# Altium schematic sheet PABX.SchDoc(PABX)
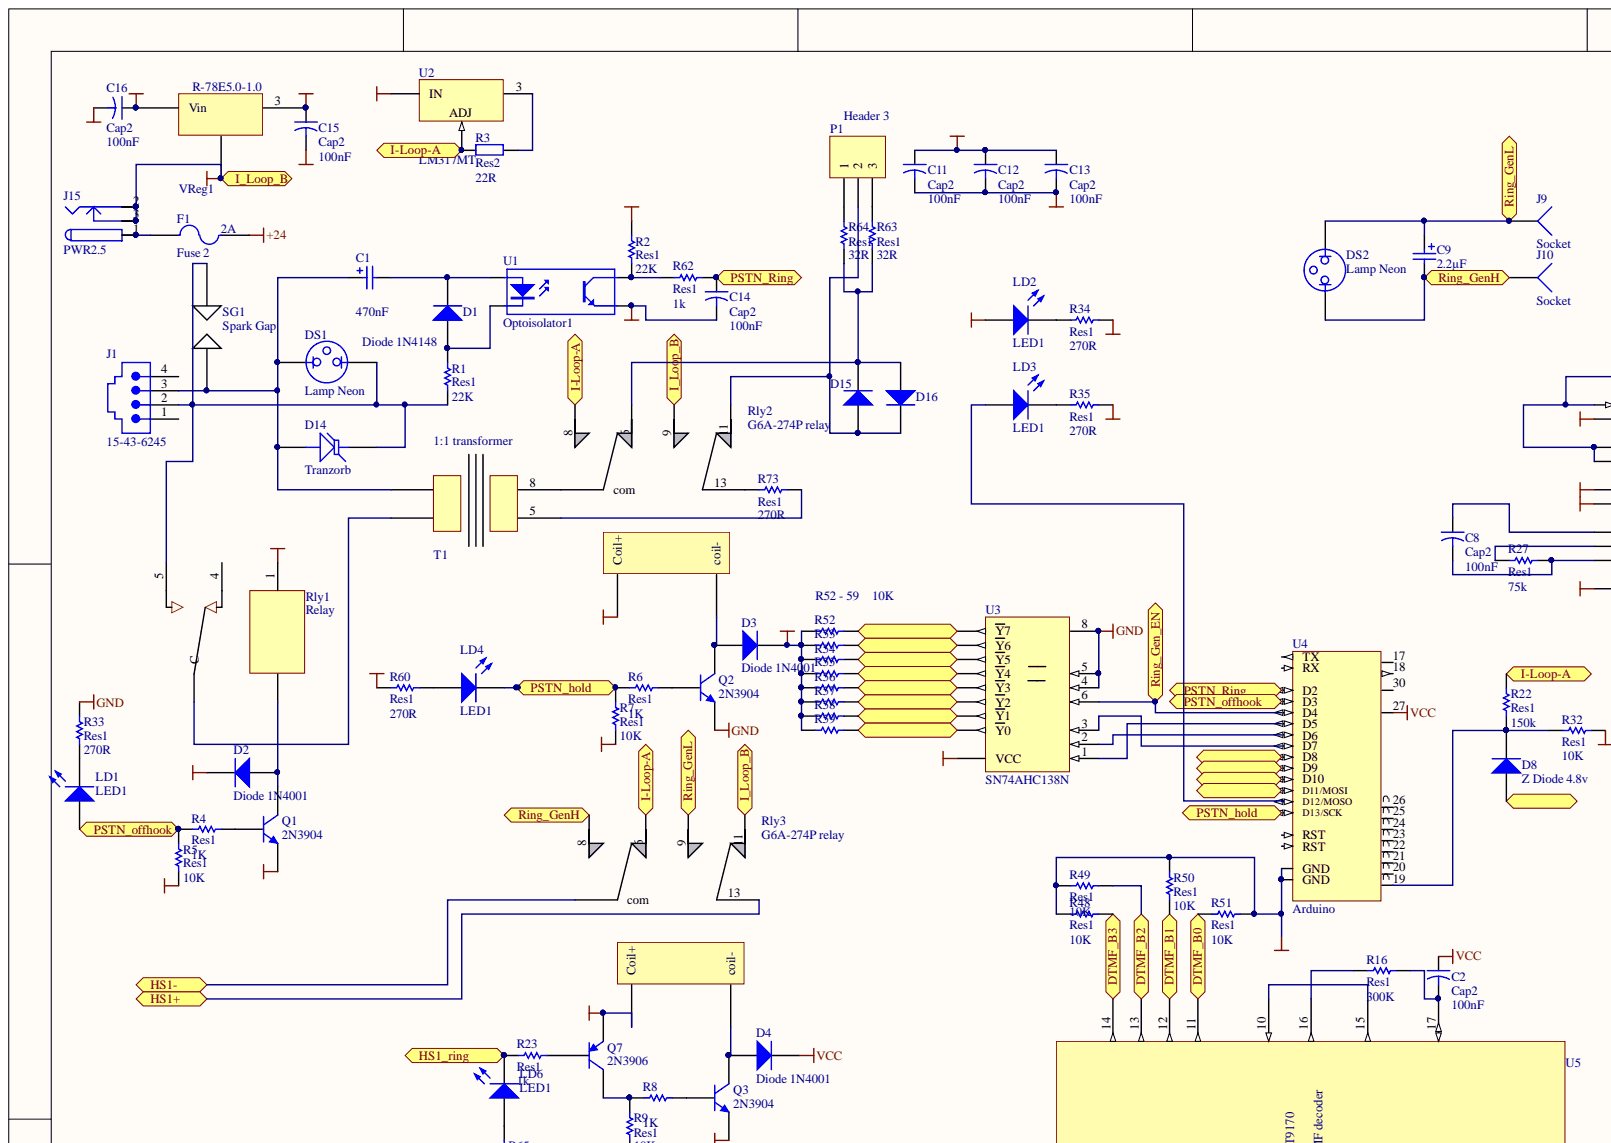
[diagram p0: PABX.SchDoc, top left]
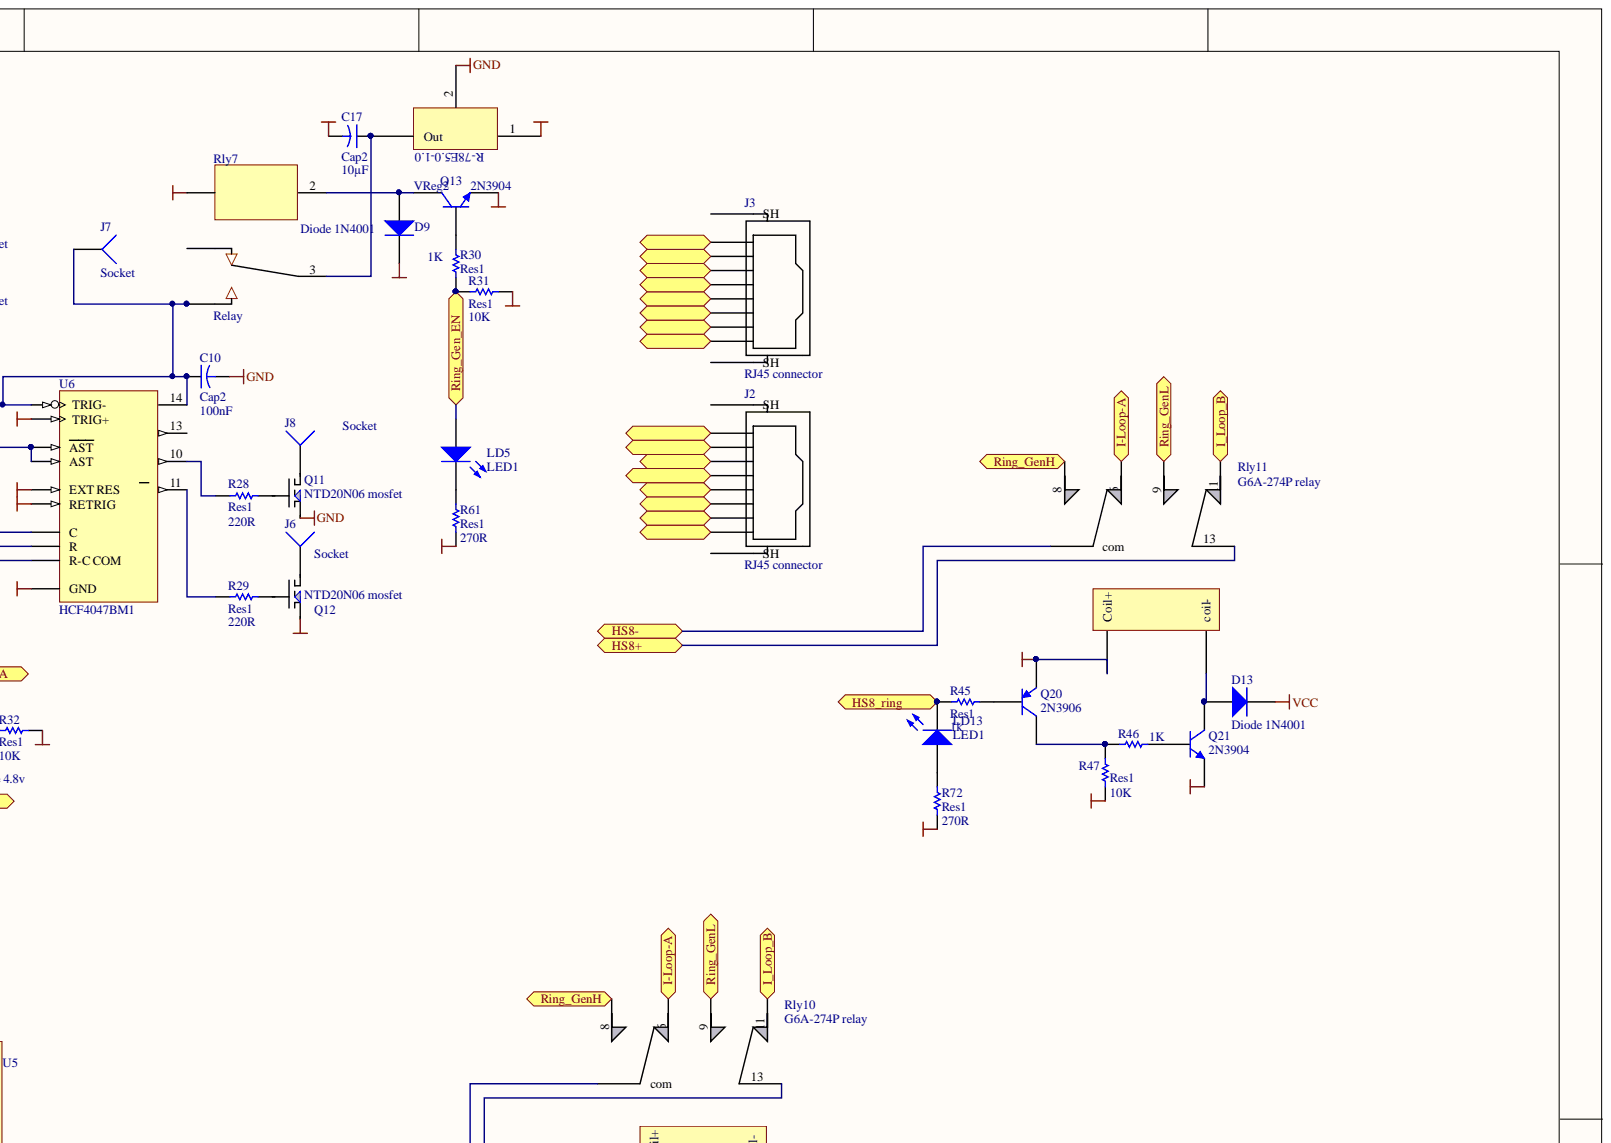
[diagram p1: PABX.SchDoc, top right]
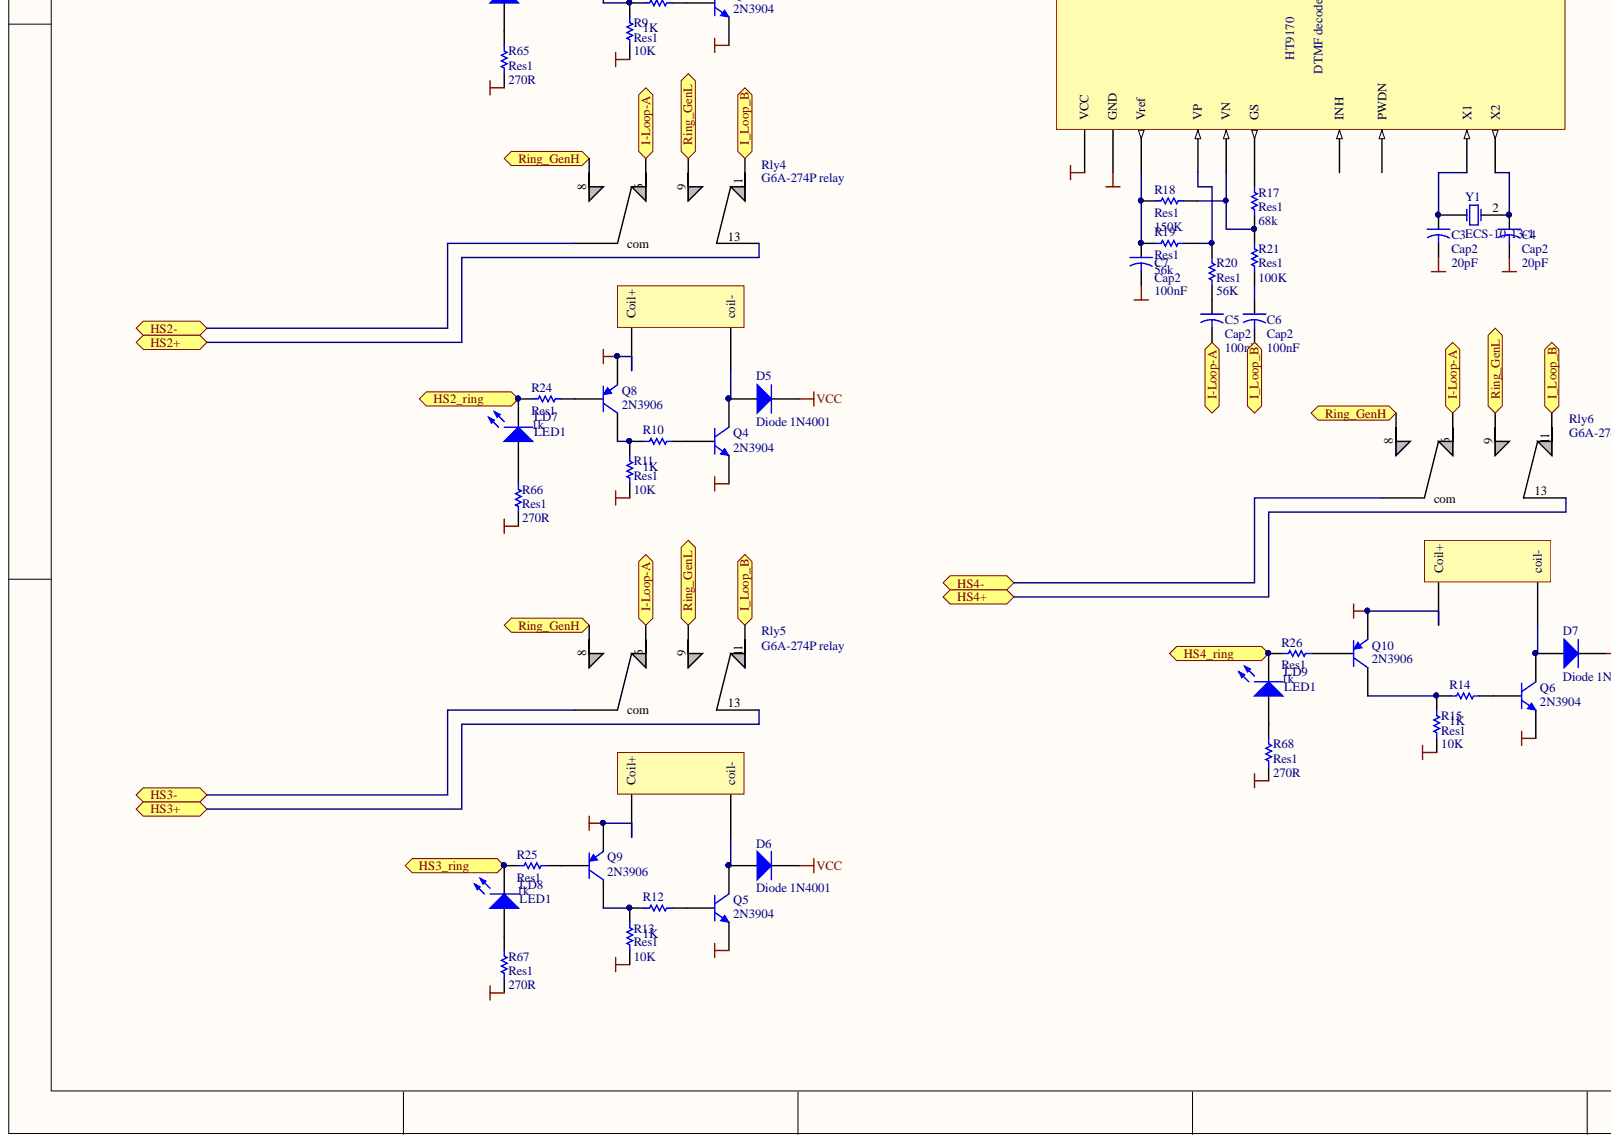
[diagram p2: PABX.SchDoc, bottom left]
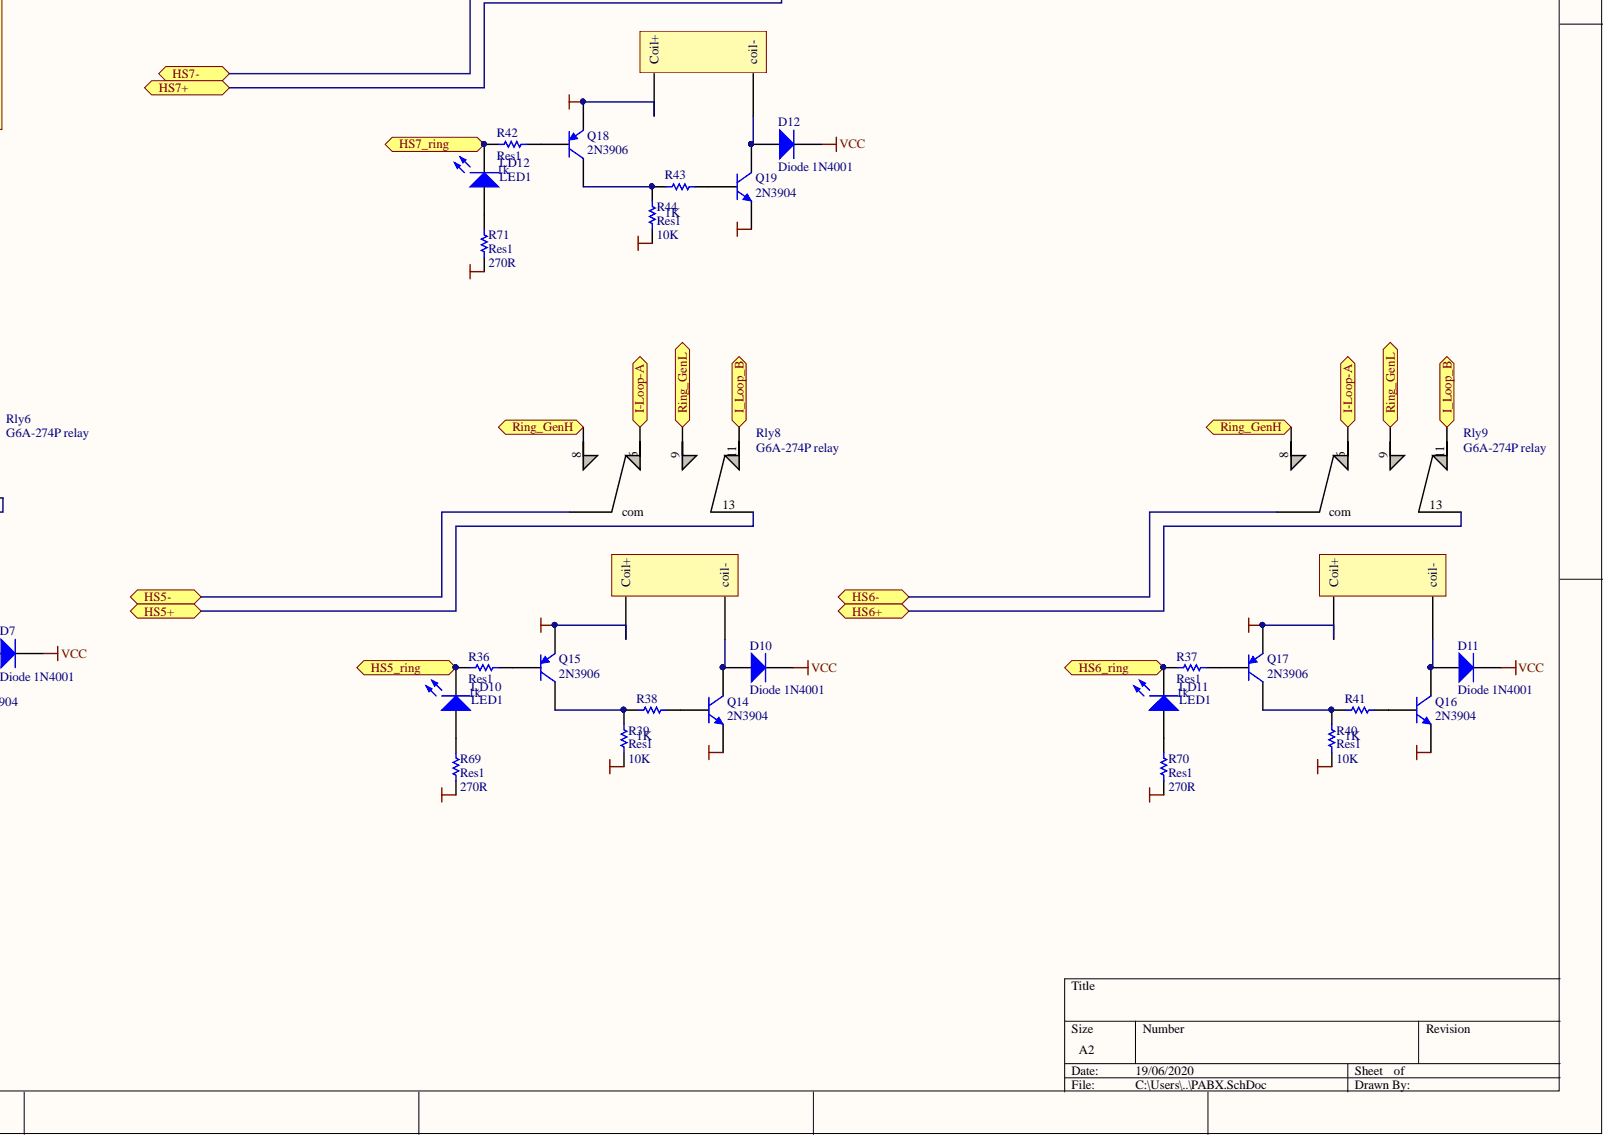
[diagram p3: PABX.SchDoc, bottom right]

## Parts
C1  value 470nF  [poly Cap]  (hidden comment: Cap Pol2)
C2  Cap2  value 100nF  [CAPR5-4X5]
C3  Cap2  value 20pF  [CAPR5-4X5]
C4  Cap2  value 20pF  [CAPR5-4X5]
C5  Cap2  value 100nF  [CAPR5-4X5]
C6  Cap2  value 100nF  [CAPR5-4X5]
C7  Cap2  value 100nF  [CAPR5-4X5]
C8  Cap2  value 100nF  [CAPR5-4X5]
C9  value 2.2µF  [poly Cap]  (hidden comment: Cap Pol2)
C10  Cap2  value 100nF  [CAPR5-4X5]
C11  Cap2  value 100nF  [CAPR5-4X5]
C12  Cap2  value 100nF  [CAPR5-4X5]
C13  Cap2  value 100nF  [CAPR5-4X5]
C14  Cap2  value 100nF  [CAPR5-4X5]
C15  Cap2  value 100nF  [CAPR5-4X5]
C16  Cap2  value 100nF  [CAPR5-4X5]
C17  Cap2  value 10µF  [CAPR5-4X5]
D1  Diode 1N4148  [DO-35]
D2  Diode 1N4001  [DO-41]
D3  Diode 1N4001  [DO-41]
D4  Diode 1N4001  [DO-41]
D5  Diode 1N4001  [DO-41]
D6  Diode 1N4001  [DO-41]
D7  Diode 1N4001  [DO-41]
D8  Z Diode 4.8v  [DO-35]
D9  Diode 1N4001  [DO-41]
D10  Diode 1N4001  [DO-41]
D11  Diode 1N4001  [DO-41]
D12  Diode 1N4001  [DO-41]
D13  Diode 1N4001  [DO-41]
D14  Tranzorb  [DO-7]
D15  Diode 1N4148  [DO-35]
D16  Diode 1N4148  [DO-35]
DS1  Lamp Neon  [PIN2]
DS2  Lamp Neon  [PIN2]
F1  Fuse 2  value 2A  [PIN-W2/E2.8]
J1  15-43-6245  [15-43-6245]
J2  RJ45 connector  [8P8C (RJ45)]
J3  RJ45 connector  [8P8C (RJ45)]
J6  Socket  [PIN1]
J7  Socket  [PIN1]
J8  Socket  [PIN1]
J9  Socket  [PIN1]
J10  Socket  [PIN1]
J15  PWR2.5  [KLD-0202]
LD1  LED1  [LED-1]
LD2  LED1  [LED-1]
LD3  LED1  [LED-1]
LD4  LED1  [LED-1]
LD5  LED1  [LED-1]
LD6  LED1  [LED-1]
LD7  LED1  [LED-1]
LD8  LED1  [LED-1]
LD9  LED1  [LED-1]
LD10  LED1  [LED-1]
LD11  LED1  [LED-1]
LD12  LED1  [LED-1]
LD13  LED1  [LED-1]
P1  Header 3  [HDR1X3]
Q1  2N3904  [TO-92A]
Q2  2N3904  [TO-92A]
Q3  2N3904  [TO-92A]
Q4  2N3904  [TO-92A]
Q5  2N3904  [TO-92A]
Q6  2N3904  [TO-92A]
Q7  2N3906  [TO-92A]
Q8  2N3906  [TO-92A]
Q9  2N3906  [TO-92A]
Q10  2N3906  [TO-92A]
Q11  NTD20N06 mosfet  [Mosfet/Dpak]
Q12  NTD20N06 mosfet  [Mosfet/Dpak]
Q13  2N3904  [TO-92A]
Q14  2N3904  [TO-92A]
Q15  2N3906  [TO-92A]
Q16  2N3904  [TO-92A]
Q17  2N3906  [TO-92A]
Q18  2N3906  [TO-92A]
Q19  2N3904  [TO-92A]
Q20  2N3906  [TO-92A]
Q21  2N3904  [TO-92A]
R1  Res1  value 22K  [AXIAL-0.3]
R2  Res1  value 22K  [AXIAL-0.3]
R3  Res2  value 22R  [AXIAL-0.4]
R4  Res1  value 1K  [AXIAL-0.3]
R5  Res1  value 10K  [AXIAL-0.3]
R6  Res1  value 1K  [AXIAL-0.3]
R7  Res1  value 10K  [AXIAL-0.3]
R8  Res1  value 1K  [AXIAL-0.3]
R9  Res1  value 10K  [AXIAL-0.3]
R10  Res1  value 1K  [AXIAL-0.3]
R11  Res1  value 10K  [AXIAL-0.3]
R12  Res1  value 1K  [AXIAL-0.3]
R13  Res1  value 10K  [AXIAL-0.3]
R14  Res1  value 1K  [AXIAL-0.3]
R15  Res1  value 10K  [AXIAL-0.3]
R16  Res1  value 300K  [AXIAL-0.3]
R17  Res1  value 68k  [AXIAL-0.3]
R18  Res1  value 150K  [AXIAL-0.3]
R19  Res1  value 56k  [AXIAL-0.3]
R20  Res1  value 56K  [AXIAL-0.3]
R21  Res1  value 100K  [AXIAL-0.3]
R22  Res1  value 150k  [AXIAL-0.3]
R23  Res1  value 1k  [AXIAL-0.3]
R24  Res1  value 1k  [AXIAL-0.3]
R25  Res1  value 1k  [AXIAL-0.3]
R26  Res1  value 1k  [AXIAL-0.3]
R27  Res1  value 75k  [AXIAL-0.3]
R28  Res1  value 220R  [AXIAL-0.3]
R29  Res1  value 220R  [AXIAL-0.3]
R30  Res1  value 1K  [AXIAL-0.3]
R31  Res1  value 10K  [AXIAL-0.3]
R32  Res1  value 10K  [AXIAL-0.3]
R33  Res1  value 270R  [AXIAL-0.3]
R34  Res1  value 270R  [AXIAL-0.3]
R35  Res1  value 270R  [AXIAL-0.3]
R36  Res1  value 1k  [AXIAL-0.3]
R37  Res1  value 1k  [AXIAL-0.3]
R38  Res1  value 1K  [AXIAL-0.3]
R39  Res1  value 10K  [AXIAL-0.3]
R40  Res1  value 10K  [AXIAL-0.3]
R41  Res1  value 1K  [AXIAL-0.3]
R42  Res1  value 1k  [AXIAL-0.3]
R43  Res1  value 1K  [AXIAL-0.3]
R44  Res1  value 10K  [AXIAL-0.3]
R45  Res1  value 1k  [AXIAL-0.3]
R46  Res1  value 1K  [AXIAL-0.3]
R47  Res1  value 10K  [AXIAL-0.3]
R48  Res1  value 10K  [AXIAL-0.3]
R49  Res1  value 10K  [AXIAL-0.3]
R50  Res1  value 10K  [AXIAL-0.3]
R51  Res1  value 10K  [AXIAL-0.3]
R52  R52 - 59  value 10K  [AXIAL-0.3]
R53  Res1  [AXIAL-0.3]  (value not drawn)
R54  Res1  [AXIAL-0.3]  (value not drawn)
R55  Res1  [AXIAL-0.3]  (value not drawn)
R56  Res1  [AXIAL-0.3]  (value not drawn)
R57  Res1  [AXIAL-0.3]  (value not drawn)
R58  Res1  [AXIAL-0.3]  (value not drawn)
R59  Res1  [AXIAL-0.3]  (value not drawn)
R60  Res1  value 270R  [AXIAL-0.3]
R61  Res1  value 270R  [AXIAL-0.3]
R62  Res1  value 1k  [AXIAL-0.3]
R63  Res1  value 32R  [AXIAL-0.3]
R64  Res1  value 32R  [AXIAL-0.3]
R65  Res1  value 270R  [AXIAL-0.3]
R66  Res1  value 270R  [AXIAL-0.3]
R67  Res1  value 270R  [AXIAL-0.3]
R68  Res1  value 270R  [AXIAL-0.3]
R69  Res1  value 270R  [AXIAL-0.3]
R70  Res1  value 270R  [AXIAL-0.3]
R71  Res1  value 270R  [AXIAL-0.3]
R72  Res1  value 270R  [AXIAL-0.3]
R73  Res1  value 270R  [AXIAL-0.3]
Rly1  Relay  [Songle Relay]
Rly2  G6A-274P relay  [G6A-274P relay]
Rly3  G6A-274P relay  [G6A-274P relay]
Rly4  G6A-274P relay  [G6A-274P relay]
Rly5  G6A-274P relay  [G6A-274P relay]
Rly6  G6A-274P relay  [G6A-274P relay]
Rly7  Relay  [Songle Relay]
Rly8  G6A-274P relay  [G6A-274P relay]
Rly9  G6A-274P relay  [G6A-274P relay]
Rly10  G6A-274P relay  [G6A-274P relay]
Rly11  G6A-274P relay  [G6A-274P relay]
SG1  Spark Gap  [Spark Gap]  (no BOM)
T1  isolation transformer  [LM-NP/-LP 1000 Series]  (value not drawn)
U1  Optoisolator1  [DIP-4]
U2  LM317MT  [TO220V]
U3  SN74AHC138N  [N016]
U4  Arduino  [Arduino Nano]
U5  HT9170 DTMF decoder IC  [HT9170]  (value not drawn)
U6  HCF4047BM1  [SO14_N]
VReg1  R-78E5.0-1.0  [R-78E5.0-1.0]
VReg2  R-78E5.0-1.0  [R-78E5.0-1.0]
Y1  ECS-10-13-1  [HC-49U]

## Ports
DTMF_B0, DTMF_B1, DTMF_B2, DTMF_B3, HS1+, HS1-, HS1_ring, HS2+, HS2-, HS2_ring, HS3+, HS3-, HS3_ring, HS4+, HS4-, HS4_ring, HS5+, HS5-, HS5_ring, HS6+, HS6-, HS6_ring, HS7+, HS7-, HS7_ring, HS8+, HS8-, HS8_ring, I-Loop-A, I_Loop_B, PSTN_hold, PSTN_offhook, PSTN_Ring, Ring_Gen_EN, Ring_GenH, Ring_GenL

## Nets
+24: C16.1 F1.2 U2.2 VReg1.1 VReg2.1
VCC: C2.1 C11.1 C12.1 C13.1 C15.1 D2.2 D3.2 D4.2 D5.2 D6.2 D7.2 D9.2 D10.2 D11.2 D12.2 D13.2 LD2.1 Q7.1 Q8.1 Q9.1 Q10.1 Q15.1 Q17.1 Q18.1 Q20.1 R2.2 R52.2 R53.2 R54.2 R55.2 R56.2 R57.2 R58.2 R59.2 R60.1 R65.1 R66.1 R67.1 R68.1 R69.1 R70.1 R71.1 R72.1 Rly1.1 Rly2.1(Coil+) Rly3.1(Coil+) Rly4.1(Coil+) Rly5.1(Coil+) Rly6.1(Coil+) Rly7.1 Rly8.1(Coil+) Rly9.1(Coil+) Rly10.1(Coil+) Rly11.1(Coil+) U3.16(VCC) U4.27 U5.18(VCC) VReg1.3
DTMF_B0: R51.1 U4.11(D8) U5.11 ; port DTMF_B0
DTMF_B1: R50.2 U4.12(D9) U5.12 ; port DTMF_B1
DTMF_B2: R49.1 U4.13(D10) U5.13 ; port DTMF_B2
DTMF_B3: R48.1 U4.14(D11/MOSI) U5.14 ; port DTMF_B3
GND: C3.2 C4.2 C6.2 C7.2 C10.2 C11.2 C12.2 C13.2 C14.2 C15.2 C16.2 C17.2 D8.1 J15.2 J15.2A J15.2B J15.3 J15.3A J15.3B Q1.1 Q2.1 Q3.1 Q4.1 Q5.1 Q6.1 Q11.3 Q12.3 Q13.1 Q14.1 Q16.1 Q19.1 Q21.1 R5.1 R7.1 R9.1 R11.1 R13.1 R15.1 R31.1 R32.1 R33.1 R34.1 R35.1 R39.1 R40.1 R44.1 R47.1 R48.2 R49.2 R50.1 R51.2 R61.1 Rly2.9 Rly3.11 Rly4.11 Rly5.11 Rly6.11 Rly8.11 Rly9.11 Rly10.11 Rly11.11 U1.3 U3.4 U3.5 U3.8 U4.4(GND) U4.29(GND) U5.9(GND) U6.7(GND) U6.8(TRIG+) U6.9(EXT_RES) U6.12(RETRIG) VReg1.2 VReg2.2 ; port I_Loop_B
HS1_ring: LD6.2 R23.1 R52.1 U3.7(Y7) ; port HS1_ring
HS2_ring: LD7.2 R24.1 R53.1 U3.9(Y6) ; port HS2_ring
HS3_ring: LD8.2 R25.1 R54.1 U3.10(Y5) ; port HS3_ring
HS4_ring: LD9.2 R26.1 R55.1 U3.11(Y4) ; port HS4_ring
HS5_ring: LD10.2 R36.1 R56.1 U3.12(Y3) ; port HS5_ring
HS6_ring: LD11.2 R37.1 R57.1 U3.13(Y2) ; port HS6_ring
HS7_ring: LD12.2 R42.1 R58.1 U3.14(Y1) ; port HS7_ring
HS8_ring: LD13.2 R45.1 R59.1 U3.15(Y0) ; port HS8_ring
I-Loop-A: C5.2 R3.1 R22.2 Rly2.8 Rly3.6 Rly4.6 Rly5.6 Rly6.6 Rly8.6 Rly9.6 Rly10.6 Rly11.6 U2.1 ; port I-Loop-A
PSTN_hold: LD4.2 R6.1 R7.2 U4.16(D13/SCK) ; port PSTN_hold
PSTN_offhook: LD1.1 R4.1 R5.2 U4.6(D3) ; port PSTN_offhook
PSTN_Ring: C14.1 R62.1 U4.5(D2) ; port PSTN_Ring
Ring_Gen_EN: LD5.1 R30.1 R31.2 U3.6 U4.7(D4) ; port Ring_Gen_EN
Ring_GenH: C9.2 DS2.1 J10.1 Rly3.8 Rly4.8 Rly5.8 Rly6.8 Rly8.8 Rly9.8 Rly10.8 Rly11.8 ; port Ring_GenH
Ring_GenL: C9.1 DS2.2 J9.1 Rly3.9 Rly4.9 Rly5.9 Rly6.9 Rly8.9 Rly9.9 Rly10.9 Rly11.9 ; port Ring_GenL
NetC1_1: C1.1 D14.1 DS1.1 J1.3 SG1.1 T1.1
NetC1_2: C1.2 D1.2 U1.1
NetC2_2: C2.2 R16.2 U5.17
NetC3_1: C3.1 U5.7(X1) Y1.1
NetC4_1: C4.1 U5.8(X2) Y1.2
NetC5_1: C5.1 R20.1
NetC6_1: C6.1 R21.1
NetC7_1: C7.1 R18.1 R19.1 U5.4(Vref)
NetC8_1: C8.1 U6.1(C)
NetC8_2: C8.2 R27.2 U6.3(R-C_COM)
NetC10_1: C10.1 J7.1 Rly7.5 U6.4(AST) U6.5(AST) U6.6(TRIG-) U6.14
NetC17_1: C17.1 Rly7.3 VReg2.3
NetD1_1: D1.1 R1.2 U1.2
NetD2_1: D2.1 Q1.3 Rly1.2
NetD3_1: D3.1 Q2.3 Rly2.16(coil-)
NetD4_1: D4.1 Q3.3 Rly3.16(coil-)
NetD5_1: D5.1 Q4.3 Rly4.16(coil-)
NetD6_1: D6.1 Q5.3 Rly5.16(coil-)
NetD7_1: D7.1 Q6.3 Rly6.16(coil-)
NetD8_2: D8.2 R22.1 R32.2 U4.19
NetD9_1: D9.1 Q13.3 Rly7.2
NetD10_1: D10.1 Q14.3 Rly8.16(coil-)
NetD11_1: D11.1 Q16.3 Rly9.16(coil-)
NetD12_1: D12.1 Q19.3 Rly10.16(coil-)
NetD13_1: D13.1 Q21.3 Rly11.16(coil-)
NetD14_2: D14.2 DS1.2 J1.2 R1.1 Rly1.5 SG1.2
NetD15_1: D15.1 D16.2 P1.2 Rly2.11
NetD15_2: D15.2 D16.1 R63.2 R64.2 Rly2.6
NetF1_1: F1.1 J15.1 J15.1A J15.1B
NetJ2_1: J2.1 Rly11.13
NetJ2_2: J2.2 Rly11.4(com)
NetJ2_3: J2.3 Rly9.13
NetJ2_4: J2.4 Rly10.13
NetJ2_5: J2.5 Rly10.4(com)
NetJ2_6: J2.6 Rly9.4(com)
NetJ2_7: J2.7 Rly8.13
NetJ2_8: J2.8 Rly8.4(com)
NetJ3_1: J3.1 Rly6.13
NetJ3_2: J3.2 Rly6.4(com)
NetJ3_3: J3.3 Rly4.13
NetJ3_4: J3.4 Rly5.13
NetJ3_5: J3.5 Rly5.4(com)
NetJ3_6: J3.6 Rly4.4(com)
NetJ3_7: J3.7 Rly3.13
NetJ3_8: J3.8 Rly3.4(com)
NetJ6_1: J6.1 Q12.2
NetJ8_1: J8.1 Q11.2
NetLD1_2: LD1.2 R33.2
NetLD2_2: LD2.2 R34.2
NetLD3_1: LD3.1 U4.15(D12/MOSO)
NetLD3_2: LD3.2 R35.2
NetLD4_1: LD4.1 R60.2
NetLD5_2: LD5.2 R61.2
NetLD6_1: LD6.1 R65.2
NetLD7_1: LD7.1 R66.2
NetLD8_1: LD8.1 R67.2
NetLD9_1: LD9.1 R68.2
NetLD10_1: LD10.1 R69.2
NetLD11_1: LD11.1 R70.2
NetLD12_1: LD12.1 R71.2
NetLD13_1: LD13.1 R72.2
NetP1_1: P1.1 R64.1
NetP1_3: P1.3 R63.1
NetQ1_2: Q1.2 R4.2
NetQ2_2: Q2.2 R6.2
NetQ3_2: Q3.2 R8.2
NetQ4_2: Q4.2 R10.2
NetQ5_2: Q5.2 R12.2
NetQ6_2: Q6.2 R14.2
NetQ7_2: Q7.2 R23.2
NetQ7_3: Q7.3 R8.1 R9.2
NetQ8_2: Q8.2 R24.2
NetQ8_3: Q8.3 R10.1 R11.2
NetQ9_2: Q9.2 R25.2
NetQ9_3: Q9.3 R12.1 R13.2
NetQ10_2: Q10.2 R26.2
NetQ10_3: Q10.3 R14.1 R15.2
NetQ11_1: Q11.1 R28.2
NetQ12_1: Q12.1 R29.2
NetQ13_2: Q13.2 R30.2
NetQ14_2: Q14.2 R38.2
NetQ15_2: Q15.2 R36.2
NetQ15_3: Q15.3 R38.1 R39.2
NetQ16_2: Q16.2 R41.2
NetQ17_2: Q17.2 R37.2
NetQ17_3: Q17.3 R40.2 R41.1
NetQ18_2: Q18.2 R42.2
NetQ18_3: Q18.3 R43.1 R44.2
NetQ19_2: Q19.2 R43.2
NetQ20_2: Q20.2 R45.2
NetQ20_3: Q20.3 R46.1 R47.2
NetQ21_2: Q21.2 R46.2
NetR2_1: R2.1 R62.2 U1.4
NetR3_2: R3.2 U2.3
NetR16_1: R16.1 U5.16
NetR17_1: R17.1 R18.2 R21.2 U5.2(VN)
NetR17_2: R17.2 U5.3(GS)
NetR19_2: R19.2 R20.2 U5.1(VP)
NetR27_1: R27.1 U6.2(R)
NetR28_1: R28.1 U6.10
NetR29_1: R29.1 U6.11
NetR73_1: R73.1 T1.5
NetR73_2: R73.2 Rly2.13
NetRly1_3: Rly1.3(C) T1.4
NetRly2_4: Rly2.4(com) T1.8
NetU3_1: U3.1 U4.8(D5)
NetU3_2: U3.2 U4.9(D6)
NetU3_3: U3.3 U4.10(D7)
NetU5_10: U5.10 U5.15

Not connected: J1 1 4; J2 9(SH) 10(SH); J3 9(SH) 10(SH); Rly1 4; Rly7 4; U4 1(TX) 2(RX) 3(RST) 17 18 20 21 22 23 24 25 26 28(RST) 30; U5 5(INH) 6(PWDN); U6 13

## Library data of the parts
C1 C9 = Cap Pol2: Polarized Capacitor (Axial); Simulation: CAP; Signal Integrity: Cap Pol; Published: 8-Jun-2000; PackageReference: POLAR0.8; PackageDescription: Polarized Capacitor; 2 Leads; Library Name: Miscellaneous Devices.IntLib; LatestRevisionNote: Re-released for DXP Platform.; LatestRevisionDate: 17-Jul-2002; Component Kind: Standard; Publisher: Altium Limited
C2-C8 C11-C15 = Cap2: Capacitor; Simulation: CAP; Signal Integrity: Cap; Published: 23-Sep-2002; PackageReference: CAPR5-4X5; PackageDescription: Capacitor; 2 Leads; Library Name: Miscellaneous Devices.IntLib; Component Kind: Standard; Publisher: Altium Limited
C10 C16 = Cap2: Capacitor; Value: 100nF; Simulation: CAP; Signal Integrity: Cap; Published: 23-Sep-2002; PackageReference: CAPR5-4X5; PackageDescription: Capacitor; 2 Leads; Library Name: Miscellaneous Devices.IntLib; Component Kind: Standard; Publisher: Altium Limited
C17 = Cap2: Capacitor; Value: 10µF; Simulation: CAP; Signal Integrity: Cap; Published: 23-Sep-2002; PackageReference: CAPR5-4X5; PackageDescription: Capacitor; 2 Leads; Library Name: Miscellaneous Devices.IntLib; Component Kind: Standard; Publisher: Altium Limited
D1 D8 D15 D16 = Diode 1N4148: High Conductance Fast Diode; Simulation: 1N4148; Signal Integrity: 1N4148; Published: 8-Jun-2000; PackageReference: DO-35; PackageDescription: Diode, Axial; Body 3.8 x 1.9 mm (LxDia. max), Lead Dia. 0.53 mm (max); Library Name: Miscellaneous Devices.IntLib; LatestRevisionNote: Re-released for DXP Platform.; LatestRevisionDate: 17-Jul-2002; DatasheetVersion: 1997; ComponentLink2URL: http://www.fairchildsemi.com/ds/1N%2F1N4148.pdf; ComponentLink2Description: Datasheet; ComponentLink1URL: http://www.fairchildsemi.com/; ComponentLink1Description: Manufacturer Link; Component Kind: Standard; Code_JEDEC: DO-204-AH; Publisher: Altium Limited
D2-D7 D9-D13 = Diode 1N4001: 1 Amp General Purpose Rectifier; Simulation: 1N4001; Signal Integrity: 1N4001; Published: 8-Jun-2000; PackageVersion: Aug-1999; PackageReference: DO-41; PackageDescription: Diode, Axial; Body 5.2 x 2.7 mm (LxDia. max), Lead Dia. 0.86 mm (max); Library Name: Miscellaneous Devices.IntLib; LatestRevisionNote: Re-released for DXP Platform.; LatestRevisionDate: 17-Jul-2002; DatasheetVersion: 1998; ComponentLink2URL: http://www.fairchildsemi.com/ds/1N%2F1N4001.pdf; ComponentLink2Description: Datasheet; ComponentLink1URL: http://www.fairchildsemi.com/; ComponentLink1Description: Manufacturer Link; Component Kind: Standard; Code_JEDEC: DO-204-AL; Publisher: Altium Limited
D14 = Tranzorb: Transient Voltage Suppression (TVS) Diode; Published: 24-Mar-1999; PackageReference: DO-7; PackageDescription: Axial Lead Diode; Library Name: Miscellaneous Devices.IntLib; LatestRevisionNote: Re-released for DXP Platform.; LatestRevisionDate: 17-Jul-2002; Component Kind: Standard; Publisher: Altium Limited
DS1 DS2 = Lamp Neon: Neon Lamp; Published: 24-Mar-1999; PackageReference: PIN2; Library Name: Miscellaneous Devices.IntLib; LatestRevisionNote: Re-released for DXP Platform.; LatestRevisionDate: 17-Jul-2002; Component Kind: Standard; Publisher: Altium Limited
F1 = Fuse 2: Fuse; Simulation: FUSE; Published: 8-Jun-2000; PackageReference: PIN-W2/E2.8; PackageDescription: Thru-Hole; 2 Leads; Library Name: Miscellaneous Devices.IntLib; LatestRevisionNote: Re-released for DXP Platform.; LatestRevisionDate: 17-Jul-2002; Component Kind: Standard; Publisher: Altium Limited
J1 = 15-43-6245: Right Angle, Standard Profile, RJ45-Keyed, Flange Mount PCB Jack; Positions/Loaded: Port1 - 8 Keyed/4; Ports: 1; PerformanceCategory: 3; PCB_Mounting: Thru-Hole; PCB_Layout: Complies with Manufacturer's Recommendation.; DrillSize: Nominal, Rounded to nearest 0.05mm; ComponentAvailability: Obsolete; Signal Integrity: Modular Jack; Published: 25-Jun-2002; PackageReference: 15-43-6245; PackageDocument: 18-Dec-2001; Library Name: Molex Modular Jack Right Angle.IntLib; DatasheetDocument: 18-Dec-2001; ComponentLink3URL: http://www.molex.com/pdm_docs/igs/15-43-6233_igs.zip; ComponentLink3Description: Iges Model; ComponentLink2URL: http://www.molex.com/cmc_upload/common_files/1212003_catalogmx41314.pdf; ComponentLink2Description: Datasheet; ComponentLink1URL: http://www.molex.com/; ComponentLink1Description: Manufacturer Link; Component Kind: Standard; Publisher: Altium Limited
J2 J3 = 8P8C (RJ45) shielded jack: RJ45 connector (8P8C); Component Kind: Standard; Library Name: williams parts lib.IntLib
J15 = PWR2.5: Low Voltage Power Supply Connector; Signal Integrity: Connector; Published: 17-Dec-2003; Library Name: Miscellaneous Connectors.IntLib; DatasheetDocument: 09-May-2002; ComponentLink1URL: http://domino.kycon.com/internet/Products.html; ComponentLink1Description: Manufacturer Link; Component Kind: Standard; Publisher: Altium Limited
LD1-LD13 = LED1: Typical RED GaAs LED; Simulation: LED1; Published: 8-Jun-2000; PackageReference: LED-1; PackageDescription: LED; 2 Leads; Library Name: Miscellaneous Devices.IntLib; LatestRevisionNote: Mech Layer 1 removed.; LatestRevisionDate: 08-Jul-2005; Component Kind: Standard; Publisher: Altium Limited
P1 = Header 3: Header, 3-Pin; Signal Integrity: Connector; Library Name: Miscellaneous Connectors.IntLib; LatestRevisionNote: Re-released for DXP Platform.; LatestRevisionDate: 17-Jul-2002; Component Kind: Standard; Publisher: Altium Limited
Q1-Q6 Q13 Q14 Q16 Q19 Q21 = 2N3904: NPN General Purpose Amplifier; Simulation: 2N3904; Signal Integrity: 2N3904; Published: 8-Jun-2000; PackageVersion: Sep-1998; PackageReference: TO-92A; PackageDescription: TO, Flat Index; 3 In-Line, Axial Leads; Body Dia. 4.5mm; Leads 0.51 x 0.48 mm (max); Library Name: Miscellaneous Devices.IntLib; LatestRevisionNote: PCB Footprint 'BCY-W3/D4.7' replaced; LatestRevisionDate: 15-Jan-2003; DatasheetVersion: 1997; ComponentLink2URL: http://www.fairchildsemi.com/ds/2N%2F2N3904.pdf; ComponentLink2Description: Datasheet; ComponentLink1URL: http://www.fairchildsemi.com/; ComponentLink1Description: Manufacturer Link; Component Kind: Standard; Publisher: Altium Limited
Q7-Q10 Q15 Q17 Q18 Q20 = 2N3906: PNP General Purpose Amplifier; Simulation: 2N3906; Signal Integrity: 2N3906; Published: 8-Jun-2000; PackageVersion: Sep-1998; PackageReference: TO-92A; PackageDescription: TO, Flat Index; 3 In-Line, Axial Leads; Body Dia. 4.5mm; Leads 0.51 x 0.48 mm (max); Library Name: Miscellaneous Devices.IntLib; LatestRevisionNote: PCB Footprint 'BCY-W3/D4.7' replaced; LatestRevisionDate: 15-Jan-2003; DatasheetVersion: 1997; ComponentLink2URL: http://www.fairchildsemi.com/ds/2N%2F2N3906.pdf; ComponentLink2Description: Datasheet; ComponentLink1URL: http://www.fairchildsemi.com/; ComponentLink1Description: Manufacturer Link; Component Kind: Standard; Publisher: Altium Limited
R1 R2 R5 R7 R9 R11 R13 R15 R17 R20-R22 R27-R35 R39 R40 R44 R46-R48 R50-R52 R60-R73 = Res1: Resistor; Simulation: RESISTOR; Signal Integrity: Res; Published: 8-Jun-2000; PackageReference: AXIAL-0.3; PackageDescription: Axial Device, Thru-Hole; 2 Leads; 0.3 in Pin Spacing; Library Name: Miscellaneous Devices.IntLib; LatestRevisionNote: Re-released for DXP Platform.; LatestRevisionDate: 17-Jul-2002; Component Kind: Standard; Publisher: Altium Limited
R3 = Res2: Resistor; Simulation: RESISTOR; Signal Integrity: Res; Published: 8-Jun-2000; PackageReference: AXIAL-0.4; PackageDescription: Axial Device, Thru-Hole; 2 Leads; 0.4 in Pin Spacing; Library Name: Miscellaneous Devices.IntLib; LatestRevisionNote: Re-released for DXP Platform.; LatestRevisionDate: 17-Jul-2002; Component Kind: Standard; Publisher: Altium Limited
R4 R6 R8 R10 R12 R14 R38 R41 R43 = Res1: Resistor; Value: 1K; Simulation: RESISTOR; Signal Integrity: Res; Published: 8-Jun-2000; PackageReference: AXIAL-0.3; PackageDescription: Axial Device, Thru-Hole; 2 Leads; 0.3 in Pin Spacing; Library Name: Miscellaneous Devices.IntLib; LatestRevisionNote: Re-released for DXP Platform.; LatestRevisionDate: 17-Jul-2002; Component Kind: Standard; Publisher: Altium Limited
R16 = Res1: Resistor; Value: 300K; Simulation: RESISTOR; Signal Integrity: Res; Published: 8-Jun-2000; PackageReference: AXIAL-0.3; PackageDescription: Axial Device, Thru-Hole; 2 Leads; 0.3 in Pin Spacing; Library Name: Miscellaneous Devices.IntLib; LatestRevisionNote: Re-released for DXP Platform.; LatestRevisionDate: 17-Jul-2002; Component Kind: Standard; Publisher: Altium Limited
R18 = Res1: Resistor; Value: 150K; Simulation: RESISTOR; Signal Integrity: Res; Published: 8-Jun-2000; PackageReference: AXIAL-0.3; PackageDescription: Axial Device, Thru-Hole; 2 Leads; 0.3 in Pin Spacing; Library Name: Miscellaneous Devices.IntLib; LatestRevisionNote: Re-released for DXP Platform.; LatestRevisionDate: 17-Jul-2002; Component Kind: Standard; Publisher: Altium Limited
R19 = Res1: Resistor; Value: 56k; Simulation: RESISTOR; Signal Integrity: Res; Published: 8-Jun-2000; PackageReference: AXIAL-0.3; PackageDescription: Axial Device, Thru-Hole; 2 Leads; 0.3 in Pin Spacing; Library Name: Miscellaneous Devices.IntLib; LatestRevisionNote: Re-released for DXP Platform.; LatestRevisionDate: 17-Jul-2002; Component Kind: Standard; Publisher: Altium Limited
R23-R26 R36 R37 R42 R45 = Res1: Resistor; Value: 1k; Simulation: RESISTOR; Signal Integrity: Res; Published: 8-Jun-2000; PackageReference: AXIAL-0.3; PackageDescription: Axial Device, Thru-Hole; 2 Leads; 0.3 in Pin Spacing; Library Name: Miscellaneous Devices.IntLib; LatestRevisionNote: Re-released for DXP Platform.; LatestRevisionDate: 17-Jul-2002; Component Kind: Standard; Publisher: Altium Limited
R49 R53-R59 = Res1: Resistor; Value: 10K; Simulation: RESISTOR; Signal Integrity: Res; Published: 8-Jun-2000; PackageReference: AXIAL-0.3; PackageDescription: Axial Device, Thru-Hole; 2 Leads; 0.3 in Pin Spacing; Library Name: Miscellaneous Devices.IntLib; LatestRevisionNote: Re-released for DXP Platform.; LatestRevisionDate: 17-Jul-2002; Component Kind: Standard; Publisher: Altium Limited
SG1 = Spark Gap: PCB foil spark gap; Library Name: PABXparts.SchLib
T1 = LM-NP/-LP 1000 Series: Description: LM-NP/-LP 1000 Series; Component Kind: Standard; Library Name: PABXparts.SchLib
U1 = Optoisolator1: 4-Pin Phototransistor Optocoupler; Published: 24-Mar-1999; PackageReference: DIP-4; Library Name: Miscellaneous Devices.IntLib; LatestRevisionNote: Sch pins 3 & 4 Swapped.; LatestRevisionDate: 04-Mar-2005; DatasheetVersion: Nov-2003; Component Kind: Standard; Publisher: Altium Limited
U2 = LM317MT: Medium Current 1.2V to 37V Adjustable Voltage Regulator; Note: Tab is connected to pin 3 (OUT).; Published: 24-Aug-2001; PackageReference: TO220V; PackageDescription: TO, Thru-Hole, Vertical, Heatsink Mounted; 3 In-Line Leads; Pitch 2.54 mm; Pin sequence 1, 3, 2; Library Name: ST Power Mgt Voltage Regulator.IntLib; LatestRevisionNote: Re-released for DXP Platform.; LatestRevisionDate: 17-Jul-2002; DatasheetVersion: Sep-1997; ComponentLink2URL: http://www.st.com/stonline/products/literature/ds/2577/lm217m.pdf; ComponentLink2Description: Datasheet; ComponentLink1URL: http://www.st.com/; ComponentLink1Description: Manufacturer Link; Component Kind: Standard; Publisher: Altium Limited
U3 = SN74AHC138N: 3-Line to 8-Line Decoder / Demultiplexer; Signal Integrity: SN74AHC138N; Published: 8-Jun-2000; PCB3D: N016; PackageVersion: Oct-1995; PackageReference: N016; PackageDescription: DIP; 16 Leads; Row Spacing 7.62 mm; Pitch 2.54 mm; LatestRevisionNote: Stylized 3D Model Added.; LatestRevisionDate: 04-Mar-2005; DatasheetVersion: Jul-1998; ComponentLink2URL: http://www-s.ti.com/sc/ds/sn74ahc138.pdf; ComponentLink2Description: Datasheet; ComponentLink1URL: http://www.ti.com/; ComponentLink1Description: Manufacturer Link; Component Kind: Standard; Code_JEDEC: MS-001-BB; Publisher: Altium Limited; Library Name: TI Logic Decoder Demux.IntLib
U4 = ArduinoNano: Component Kind: Standard; Library Name: arduino stuff.SchLib
U5 = HT9170: Component Kind: Standard; Library Name: PABXparts.SchLib
U6 = HCF4047BM1: Low-Power Monostable/Astable Multivibrator; Published: 8-Jun-2000; PCB3D: SO14; PackageVersion: Sep-1998; PackageReference: SO14; PackageDescription: 14-Pin Small Outline Integrated Circuit 1.27 mm Pitch; Library Name: ST Logic Multivibrator.IntLib; LatestRevisionNote: IPC-7351 Footprint Added.; LatestRevisionDate: 13-Apr-2006; DatasheetVersion: Jun-1989; ComponentLink2URL: http://www.st.com/stonline/products/literature/ds/2050/hcf4047.pdf; ComponentLink2Description: Datasheet; ComponentLink1URL: http://www.st.com/; ComponentLink1Description: Manufacturer Link; Component Kind: Standard; Code_IPC: SOIC127P600-14; Publisher: Altium Limited
VReg1 VReg2 = R-78E5.0-1.0: R-78E*-1.0 series regulator; Component Kind: Standard; Library Name: PABXparts.SchLib
Y1 = ECS-10-13-1: Crystal Oscillator; Simulation: XTAL; Published: 17-Jul-2002; PackageReference: HC-49U; Library Name: ECS Crystal Oscillator.IntLib; DatasheetDocument: 18-Apr-2000; ComponentLink2URL: http://www.ecsxtal.com/pdf2/HC49U.PDF; ComponentLink2Description: Datasheet; ComponentLink1URL: http://www.ecsxtal.com/; ComponentLink1Description: Manufacturer Link; Component Kind: Standard; Publisher: Altium Limited
J6-J10 = Socket: Signal Integrity: Connector; Library Name: Miscellaneous Connectors.IntLib; LatestRevisionNote: Re-released for DXP Platform.; LatestRevisionDate: 17-Jul-2002; Description: Socket; Component Kind: Standard; Publisher: Altium Limited
Q11 Q12 = NTD20N06 mosfet: Component Kind: Standard; Library Name: current mon.SchLib
Rly1 Rly7 = Relay: Component Kind: Standard; Library Name: current mon.SchLib
Rly2-Rly6 Rly8-Rly11 = G6A-274P relay: Component Kind: Standard; Library Name: PABXparts.SchLib
